# Altium schematic sheet pushbuttons_and_7Seg.SchDoc
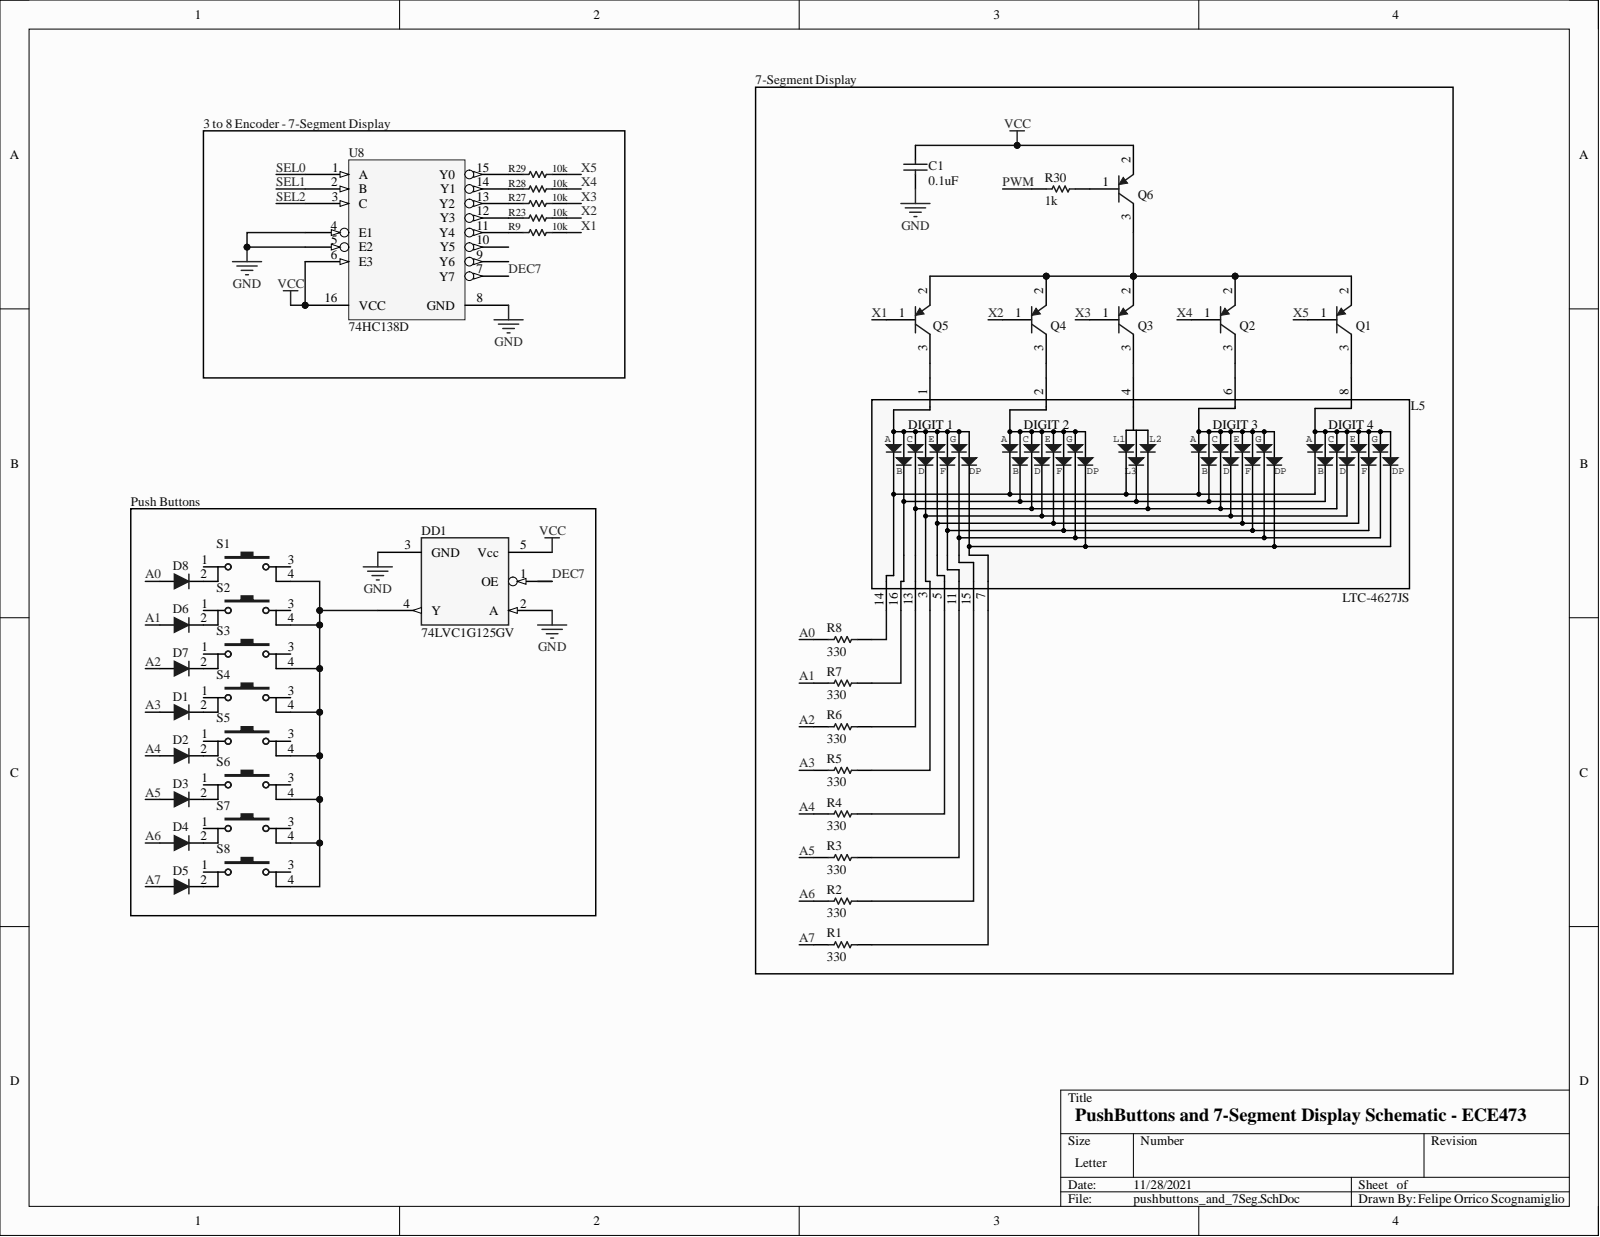
[diagram p0: pushbuttons_and_7Seg.SchDoc]

## Parts
C1  0.1uF  [PCB-414doup3lqi1tfwm7xsm-1]
D1  KC_ON Semiconductor 1N4148TR rev B  [PCB-padpmv4vaux39afdx1yf-3]  (value not drawn)
D2  KC_ON Semiconductor 1N4148TR rev B  [PCB-padpmv4vaux39afdx1yf-3]  (value not drawn)
D3  KC_ON Semiconductor 1N4148TR rev B  [PCB-padpmv4vaux39afdx1yf-3]  (value not drawn)
D4  KC_ON Semiconductor 1N4148TR rev B  [PCB-padpmv4vaux39afdx1yf-3]  (value not drawn)
D5  KC_ON Semiconductor 1N4148TR rev B  [PCB-padpmv4vaux39afdx1yf-3]  (value not drawn)
D6  KC_ON Semiconductor 1N4148TR rev B  [PCB-padpmv4vaux39afdx1yf-3]  (value not drawn)
D7  KC_ON Semiconductor 1N4148TR rev B  [PCB-padpmv4vaux39afdx1yf-3]  (value not drawn)
D8  KC_ON Semiconductor 1N4148TR rev B  [PCB-padpmv4vaux39afdx1yf-3]  (value not drawn)
DD1  74LVC1G125GV  [PCB-1346775-1]
L5  LTC-4627JS  [PCB-ynnpp22agni8zn9n7d6x-1]
Q1  MMBT4403  [ONSC-SC-70-3-419-04_V]  (value not drawn)
Q2  MMBT4403  [ONSC-SC-70-3-419-04_V]  (value not drawn)
Q3  MMBT4403  [ONSC-SC-70-3-419-04_V]  (value not drawn)
Q4  MMBT4403  [ONSC-SC-70-3-419-04_V]  (value not drawn)
Q5  (no value)  [ONSC-SC-70-3-419-04_V]
Q6  MMBT4403  [ONSC-SC-70-3-419-04_V]  (value not drawn)
R1  330  [PCB-q466dezs08uszq8774n0-1]
R2  330  [PCB-q466dezs08uszq8774n0-1]
R3  330  [PCB-q466dezs08uszq8774n0-1]
R4  330  [PCB-q466dezs08uszq8774n0-1]
R5  330  [PCB-q466dezs08uszq8774n0-1]
R6  330  [PCB-q466dezs08uszq8774n0-1]
R7  330  [PCB-q466dezs08uszq8774n0-1]
R8  330  [PCB-q466dezs08uszq8774n0-1]
R9  10k  [PCB-q466dezs08uszq8774n0-1]
R23  10k  [PCB-q466dezs08uszq8774n0-1]
R27  10k  [PCB-q466dezs08uszq8774n0-1]
R28  10k  [PCB-q466dezs08uszq8774n0-1]
R29  10k  [PCB-q466dezs08uszq8774n0-1]
R30  1k  [PCB-q466dezs08uszq8774n0-1]
S1  TE Connectivity 2-1437565-9  [PCB-jknttd96a2zks7mphmau-2]  (value not drawn)
S2  TE Connectivity 2-1437565-9  [PCB-jknttd96a2zks7mphmau-2]  (value not drawn)
S3  TE Connectivity 2-1437565-9  [PCB-jknttd96a2zks7mphmau-2]  (value not drawn)
S4  TE Connectivity 2-1437565-9  [PCB-jknttd96a2zks7mphmau-2]  (value not drawn)
S5  TE Connectivity 2-1437565-9  [PCB-jknttd96a2zks7mphmau-2]  (value not drawn)
S6  TE Connectivity 2-1437565-9  [PCB-jknttd96a2zks7mphmau-2]  (value not drawn)
S7  TE Connectivity 2-1437565-9  [PCB-jknttd96a2zks7mphmau-2]  (value not drawn)
S8  TE Connectivity 2-1437565-9  [PCB-jknttd96a2zks7mphmau-2]  (value not drawn)
U8  74HC138D  [PCB-90d6w68y3bxsno1m2lnt-1]

## Nets
GND: C1.1 DD1.2(A) DD1.3(GND) U8.4(E1) U8.5(E2) U8.8(GND)
VCC: C1.2 DD1.5(Vcc) Q6.2 U8.6(E3) U8.16(VCC)
A0: D8.1 R8.1
A1: D6.1 R7.1
A2: D7.1 R6.1
A3: D1.1 R5.1
A4: D2.1 R4.1
A5: D3.1 R3.1
A6: D4.1 R2.1
A7: D5.1 R1.1
DEC7: DD1.1(OE) U8.7(Y7)
PWM: R30.1
SEL0: U8.1(A)
SEL1: U8.2(B)
SEL2: U8.3(C)
X1: Q5.1 R9.2
X2: Q4.1 R23.2
X3: Q3.1 R27.2
X4: Q2.1 R28.2
X5: Q1.1 R29.2
NetD1_2: D1.2 S4.2
NetD2_2: D2.2 S5.2
NetD3_2: D3.2 S6.2
NetD4_2: D4.2 S7.2
NetD5_2: D5.2 S8.2
NetD6_2: D6.2 S2.2
NetD7_2: D7.2 S3.2
NetD8_2: D8.2 S1.2
NetDD1_4: DD1.4(Y) S1.4 S2.4 S3.4 S4.4 S5.4 S6.4 S7.4 S8.4
NetL5_1: L5.1 Q5.3
NetL5_2: L5.2 Q4.3
NetL5_3: L5.3 R5.2
NetL5_4: L5.4 Q3.3
NetL5_5: L5.5 R4.2
NetL5_6: L5.6 Q2.3
NetL5_7: L5.7 R1.2
NetL5_8: L5.8 Q1.3
NetL5_11: L5.11 R3.2
NetL5_13: L5.13 R6.2
NetL5_14: L5.14 R8.2
NetL5_15: L5.15 R2.2
NetL5_16: L5.16 R7.2
NetQ1_2: Q1.2 Q2.2 Q3.2 Q4.2 Q5.2 Q6.3
NetQ6_1: Q6.1 R30.2
NetR9_1: R9.1 U8.11(Y4)
NetR23_1: R23.1 U8.12(Y3)
NetR27_1: R27.1 U8.13(Y2)
NetR28_1: R28.1 U8.14(Y1)
NetR29_1: R29.1 U8.15(Y0)

Not connected: S1 1 3; S2 1 3; S3 1 3; S4 1 3; S5 1 3; S6 1 3; S7 1 3; S8 1 3; U8 9(Y6) 10(Y5)

## Library data of the parts
C1 = CMP-a01d69675d8a7fda-13: Cap Ceramic 0.1uF 50V X7R 10% Radial 2.54mm 125°C Bulk; Component Kind: Standard; Library Name: My Personal Space
D1-D8 = CMP-5cb695b893498371-11: Diode, Small Signal; 300 mA; 100 V (Min.); 1 V (Max.); 440 mW; 350 K/W; 100 V Rev - b; Breakdown Voltage: 100 V (min); Capacitance: 4.00 pF; Current Rating: 200 mA; Forward Voltage: 1.00 V; Lead-Free Status: Lead Free; Output Current: 200 mA (max); Polarity: Standard; Power Dissipation: 500 mW (max); Voltage Rating (DC): 100 V; Case/Package: DO-35; Component Kind: Standard; Library Name: My Personal Space; Lifecycle Status: Active; Manufacturer Part Number: 1N4148TR; Mounting Style: Through Hole; Number of Pins: 2; Octopart URL: https://octopart.com/1n4148tr-on+semiconductor-84323125; OctopartID: 39dcf5d0b78fe61b; Operating Temperature: -65.0 °C to 175 °C; Packaging: Tape; RoHS: Compliant
DD1 = CMP-1346775-2: IC BUFF DVR TRI-ST N-INV 5TSOP; Manufacturer Part Number: 74LVC1G125GV,125; Lead-Free Status: Lead Free; Component Kind: Standard; Library Name: My Personal Space; Lifecycle Status: Active; Number of Pins: 5; Octopart Url: https://octopart.com/74lvc1g125gv%2C125-nxp+semiconductors-10475972; OctopartID: 62ebab7e9e49bd2c; Packaging: Tape & Reel (TR); REACH SVHC Compliance: No SVHC; RoHS: Compliant
L5 = CMP-b5b87cbace936a7f-1: Displays Segmented Panel 4DIGIT 35LED Yellow CA 16-Pin DIP; Character Size-Height: 10.0 mm; Pin Pitch: 2.54 mm; Case/Package: DIP; Color: Yellow; Component Kind: Standard; Library Name: My Personal Space; Manufacturer Part Number: LTC-4627JS; Mounting Style: Through Hole; Number of Pins: 16; Octopart URL: http://octopart.com/ltc-4627js-lite-on-548429; OctopartID: b5b87cbace936a7f; Packaging: Tube; Size-Height: 7.00 mm; Size-Length: 40.2 mm; Size-Width: 12.8 mm
Q1-Q6 = CMP-860742-2: Switching Transistor, PNP Silicon, 3-Pin SC70, Pb-Free, Tape and Reel; Manufacturer Part Number: MMBT4403WT1G; Current Rating: -0.6 A; Lead-Free Status: Lead Free; Voltage Rating (DC): -40.0 V; Component Kind: Standard; Library Name: My Personal Space; Lifecycle Status: Active; Mounting Style: Surface Mount; Number of Pins: 3; Octopart Url: https://octopart.com/mmbt4403wt1g-on+semiconductor-357937; OctopartID: 0eb6c7b95de1d171; Packaging: Tape; Polarity: PNP; RoHS: Compliant
R1-R9 R23 R27-R30 = CMP-e82f23a0358237e8-1: RC Series 0201 0.05 W 1 kOhms 5% ±200 ppm/°C SMT Thick Film Chip Resistor; Manufacturer Part Number: RC0201JR-071KL; Lead-Free Status: Lead Free; Power Rating: 50.0 mW; Resistance: 1.00 kΩ; Voltage Rating (DC): 25.0 V; Case/Package: 0201; Component Kind: Standard; Library Name: My Personal Space; Mounting Style: Surface Mount; Number of Pins: 2; Octopart URL: http://octopart.com/rc0201jr-071kl-yageo-42334411; OctopartID: e82f23a0358237e8; Packaging: Tape & Reel; REACH SVHC Compliance: No SVHC; Resistance Tolerance: ±5%; RoHS: Compliant; Size-Length: 600 µm
S1-S8 = CMP-34bcffd9c077eb7b-4: Switch Tactile OFF (ON) SPST Round Button Gull Wing 0.05A 24VDC 1VA 9.18N SMD T/R; Component Kind: Standard; Library Name: My Personal Space
U8 = CMP-0ab9c3139318d57e-1: 74HC Series 6 V 3-to-8 Line Decoder/Demultiplexer Inverting - SOIC-16; Manufacturer Part Number: 74HC138D,653; Lead-Free Status: Lead Free; Number of Gates: 1; Number of Outputs: 8; Component Kind: Standard; Library Name: My Personal Space; Lifecycle Status: Active; Mounting Style: Surface Mount; Number of Pins: 16; Octopart URL: http://octopart.com/74hc138d%2C653-nxp+semiconductors-10534087; OctopartID: 0ab9c3139318d57e; Packaging: Tape & Reel (TR); REACH SVHC Compliance: No SVHC; RoHS: Compliant; Supply Voltage (DC): V
